# Altium schematic sheet keyboard.SchDoc
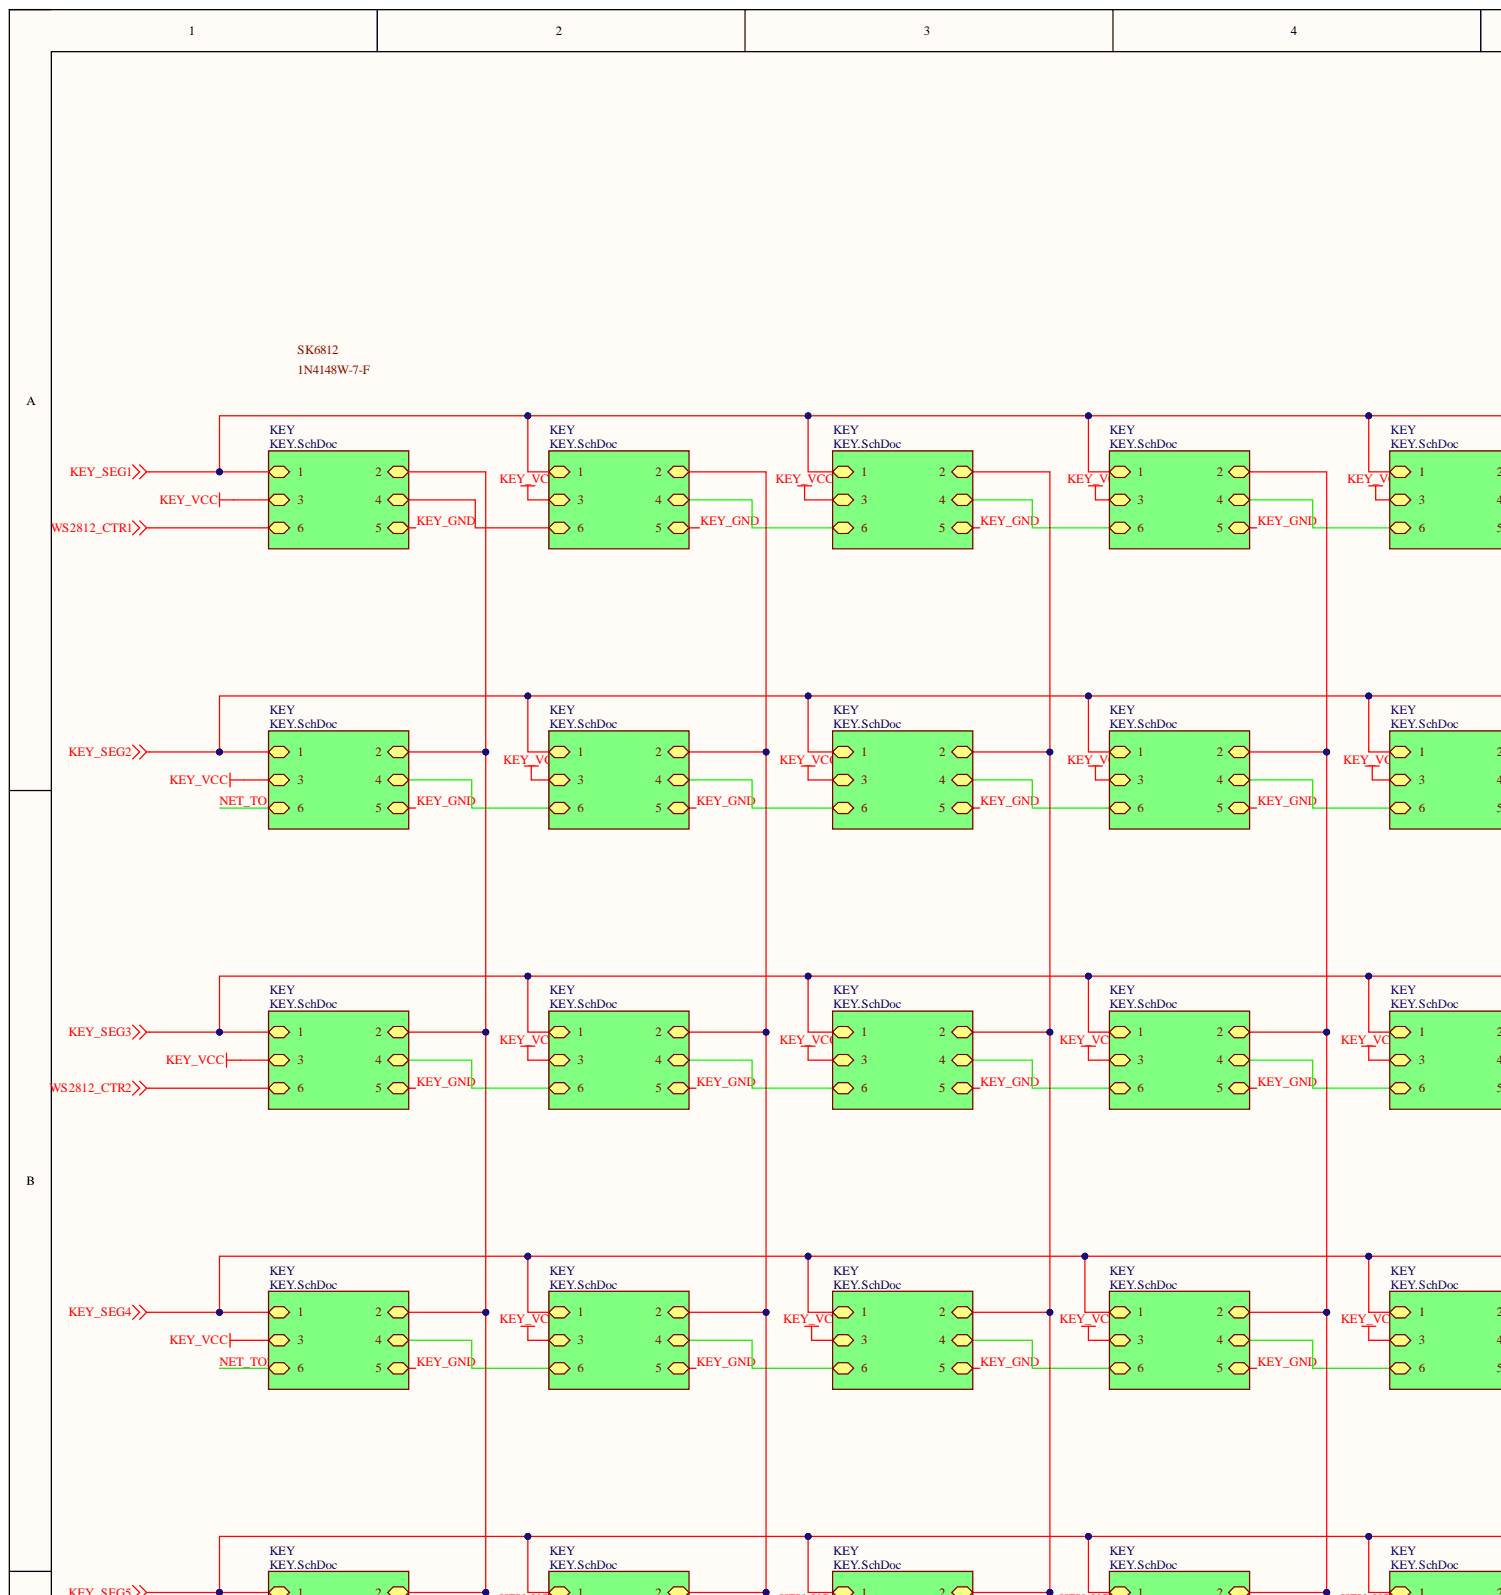
[diagram p0: keyboard.SchDoc, top left]
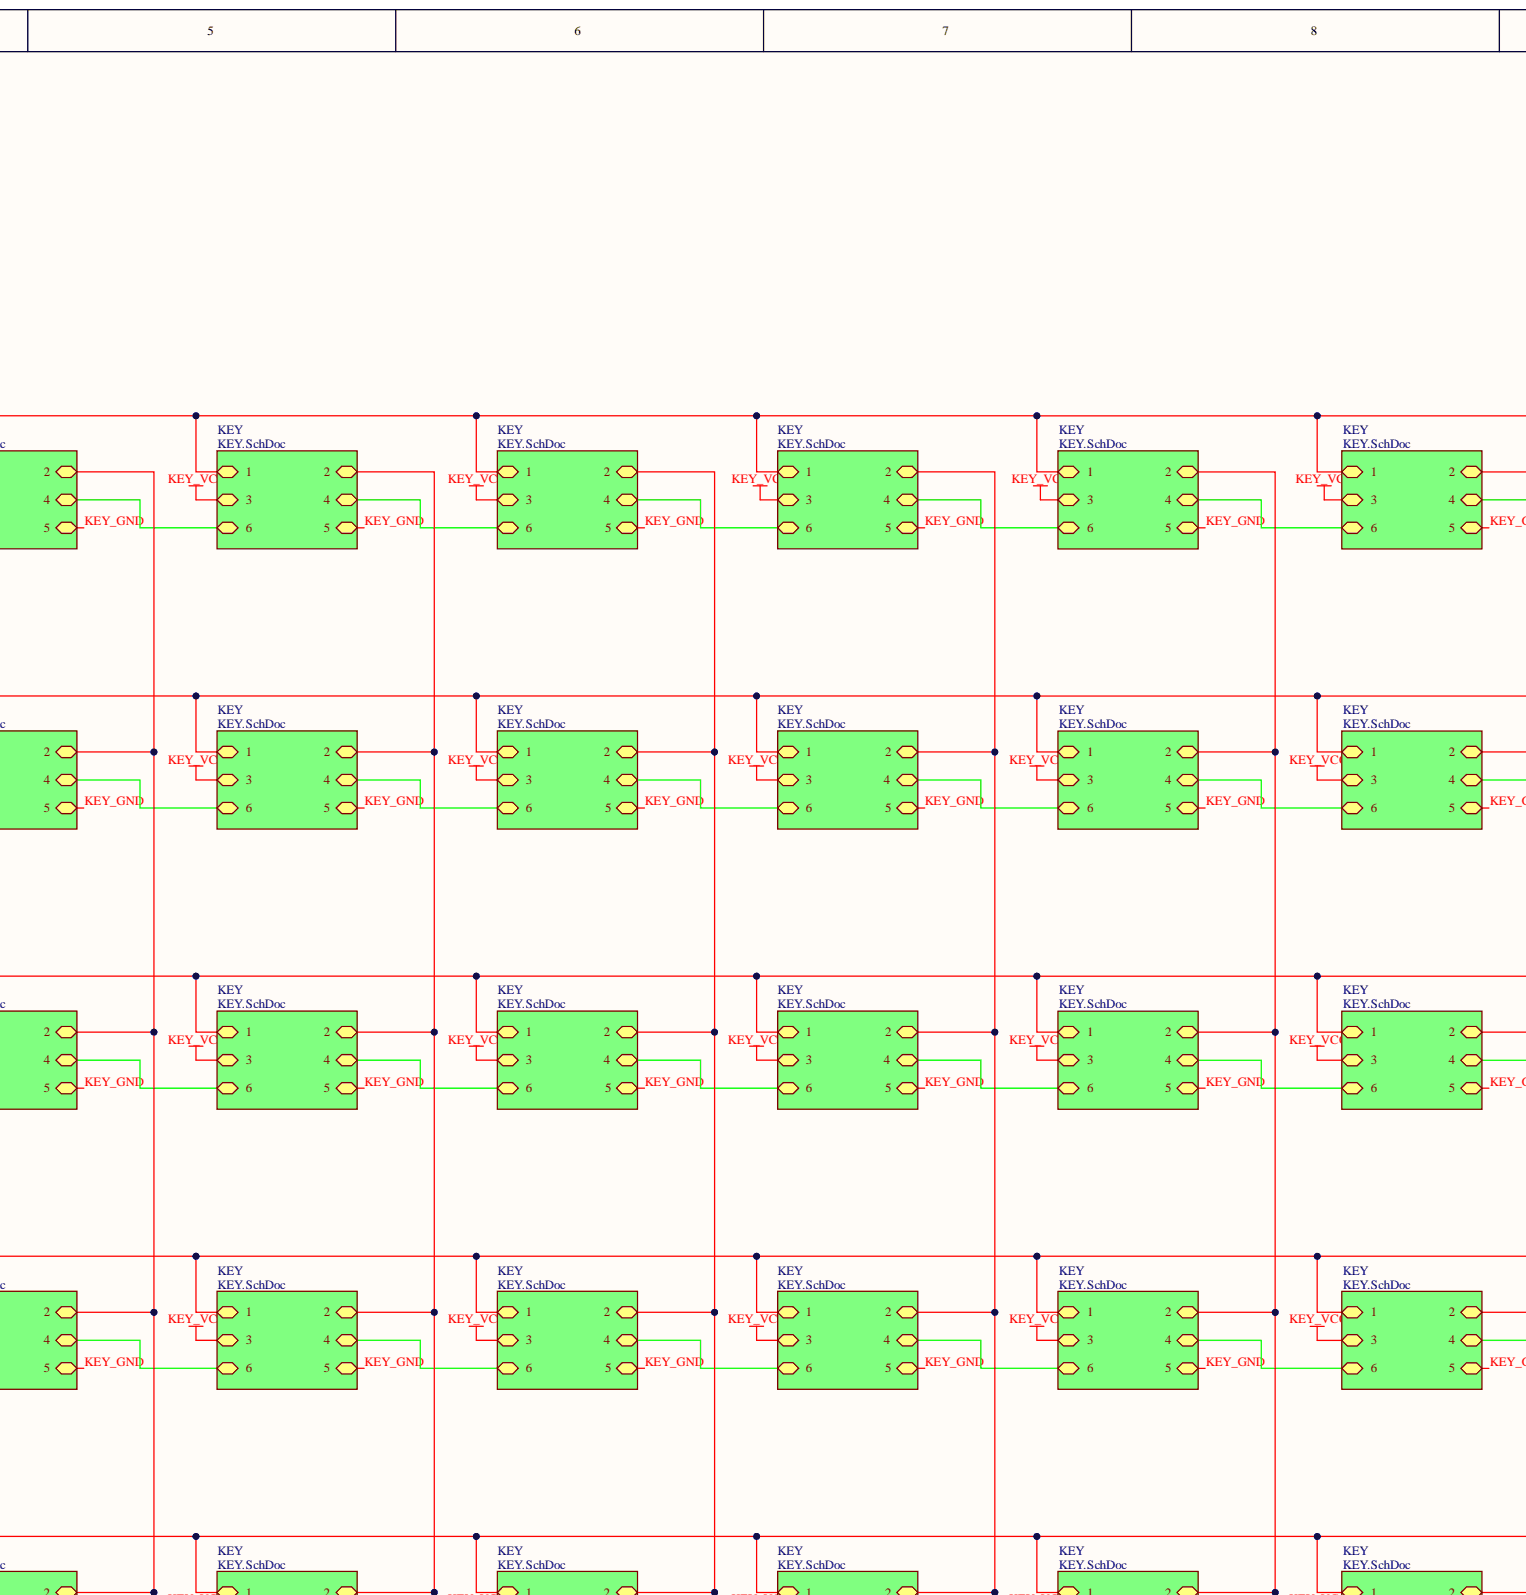
[diagram p1: keyboard.SchDoc, top centre]
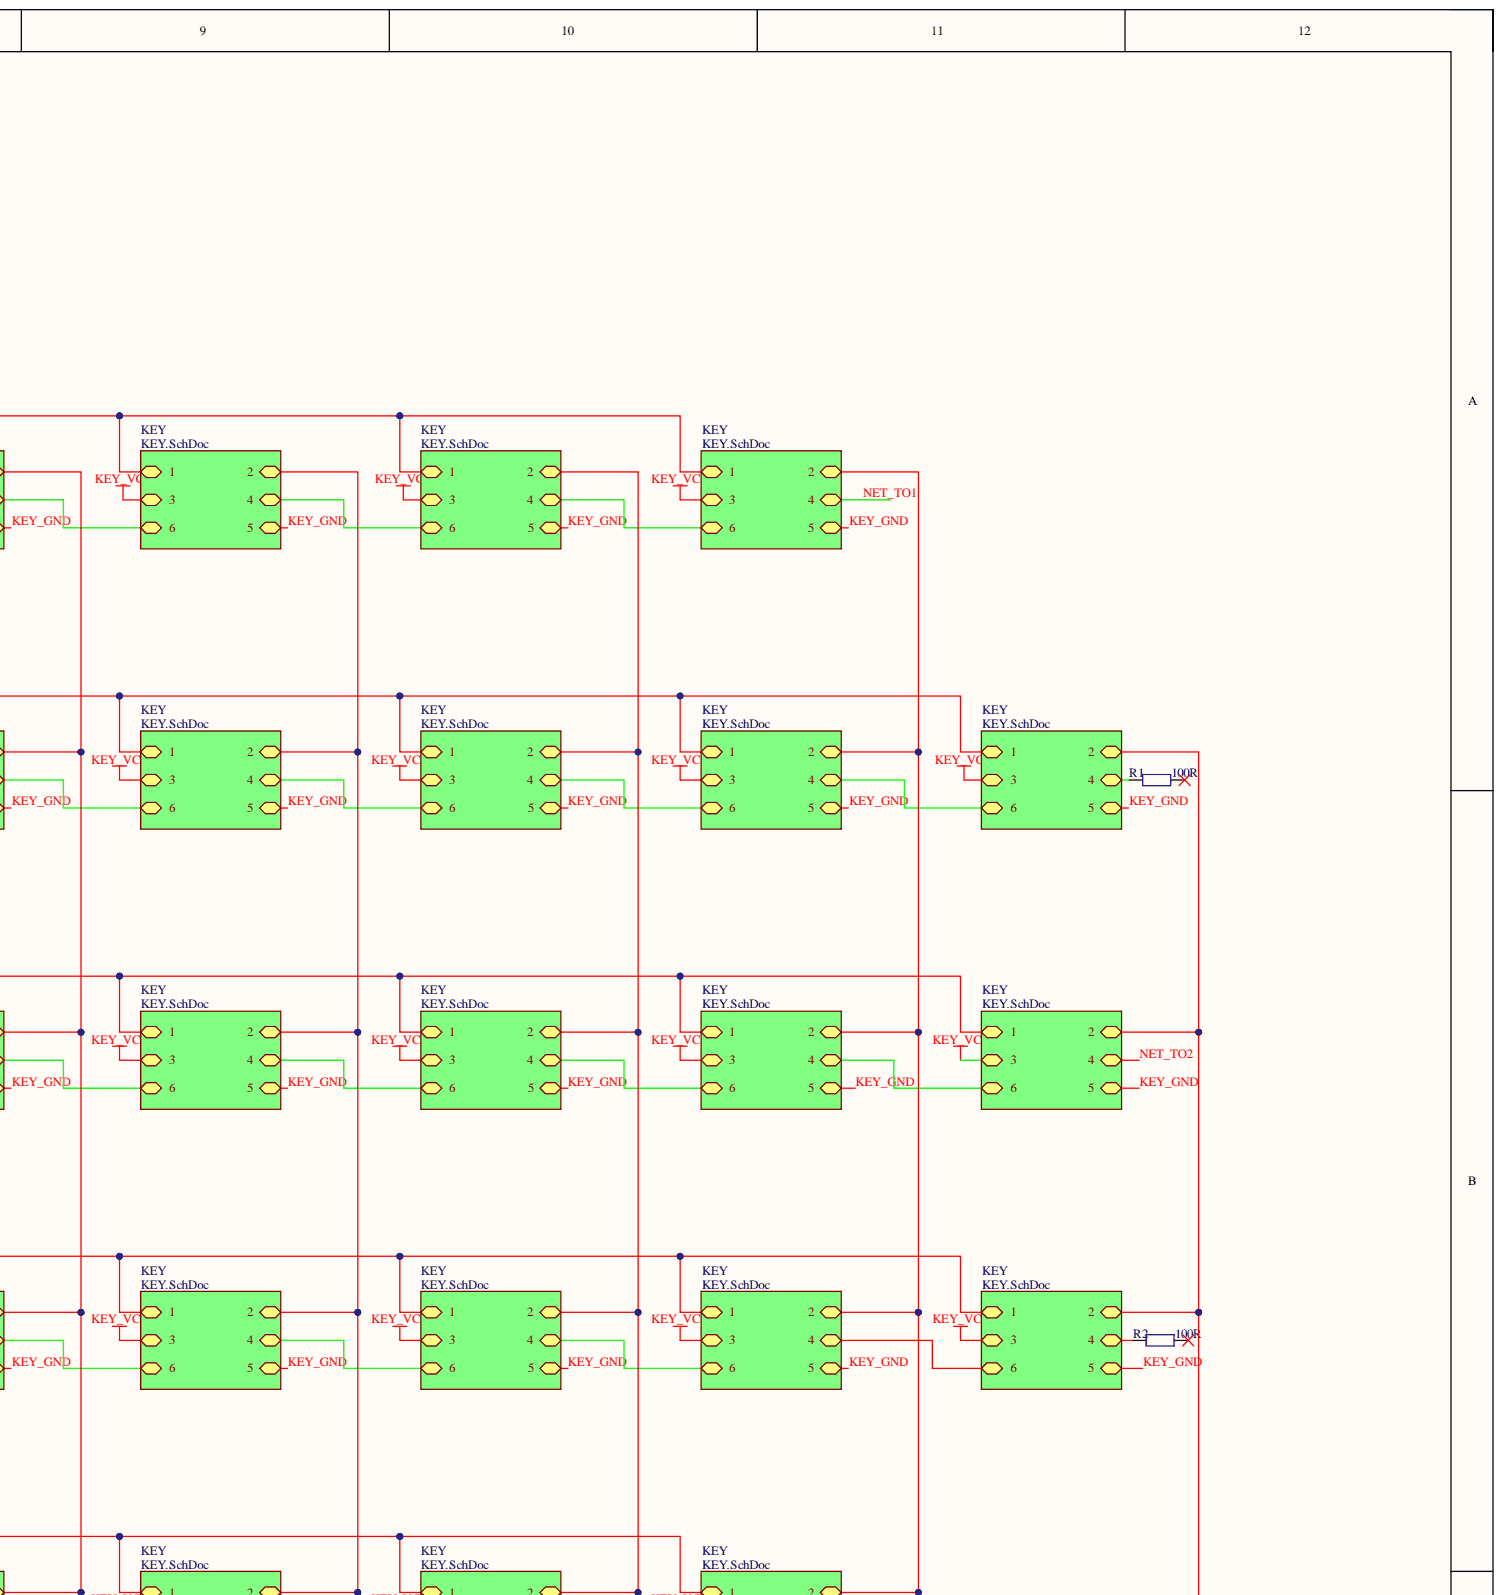
[diagram p2: keyboard.SchDoc, top right]
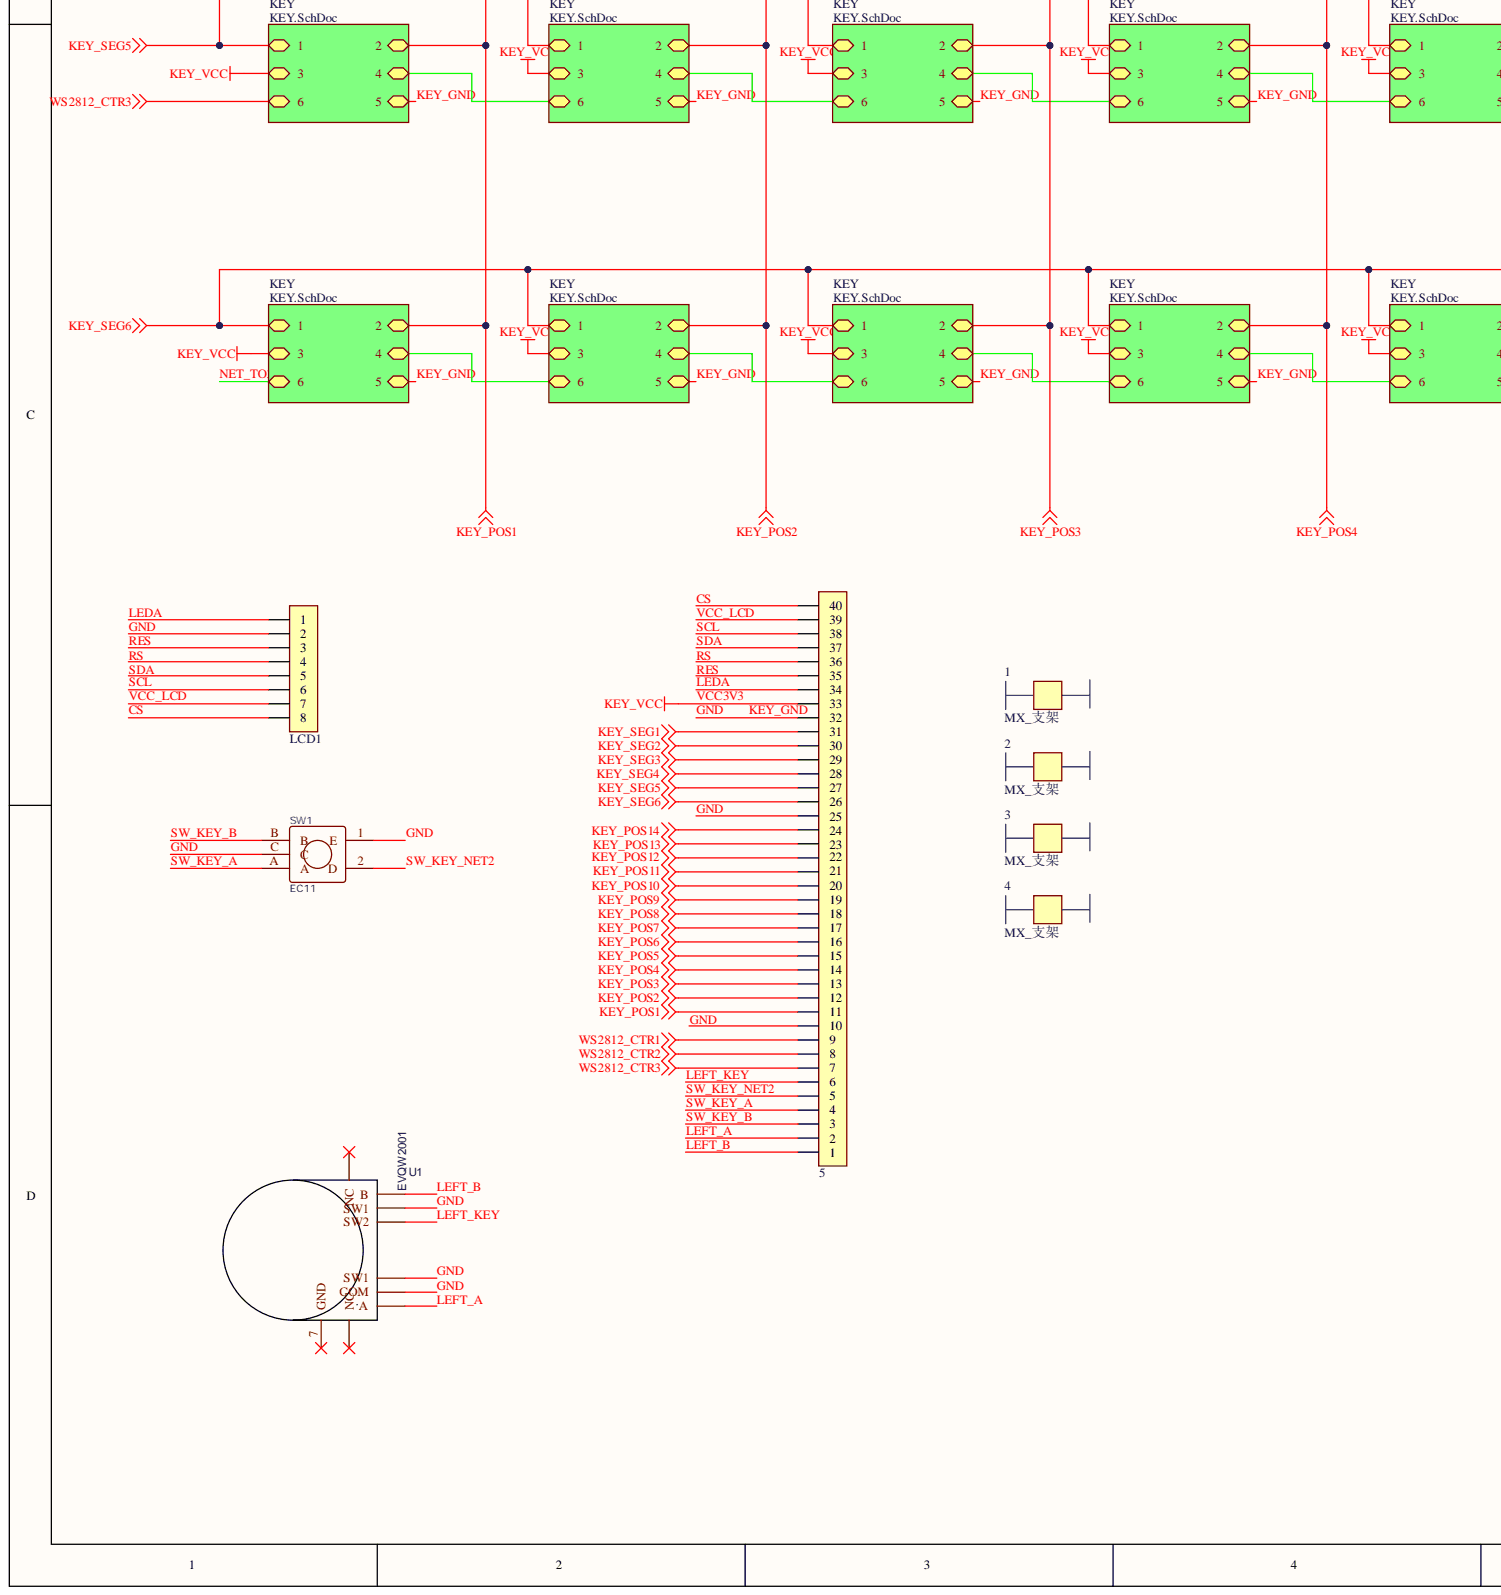
[diagram p3: keyboard.SchDoc, bottom left]
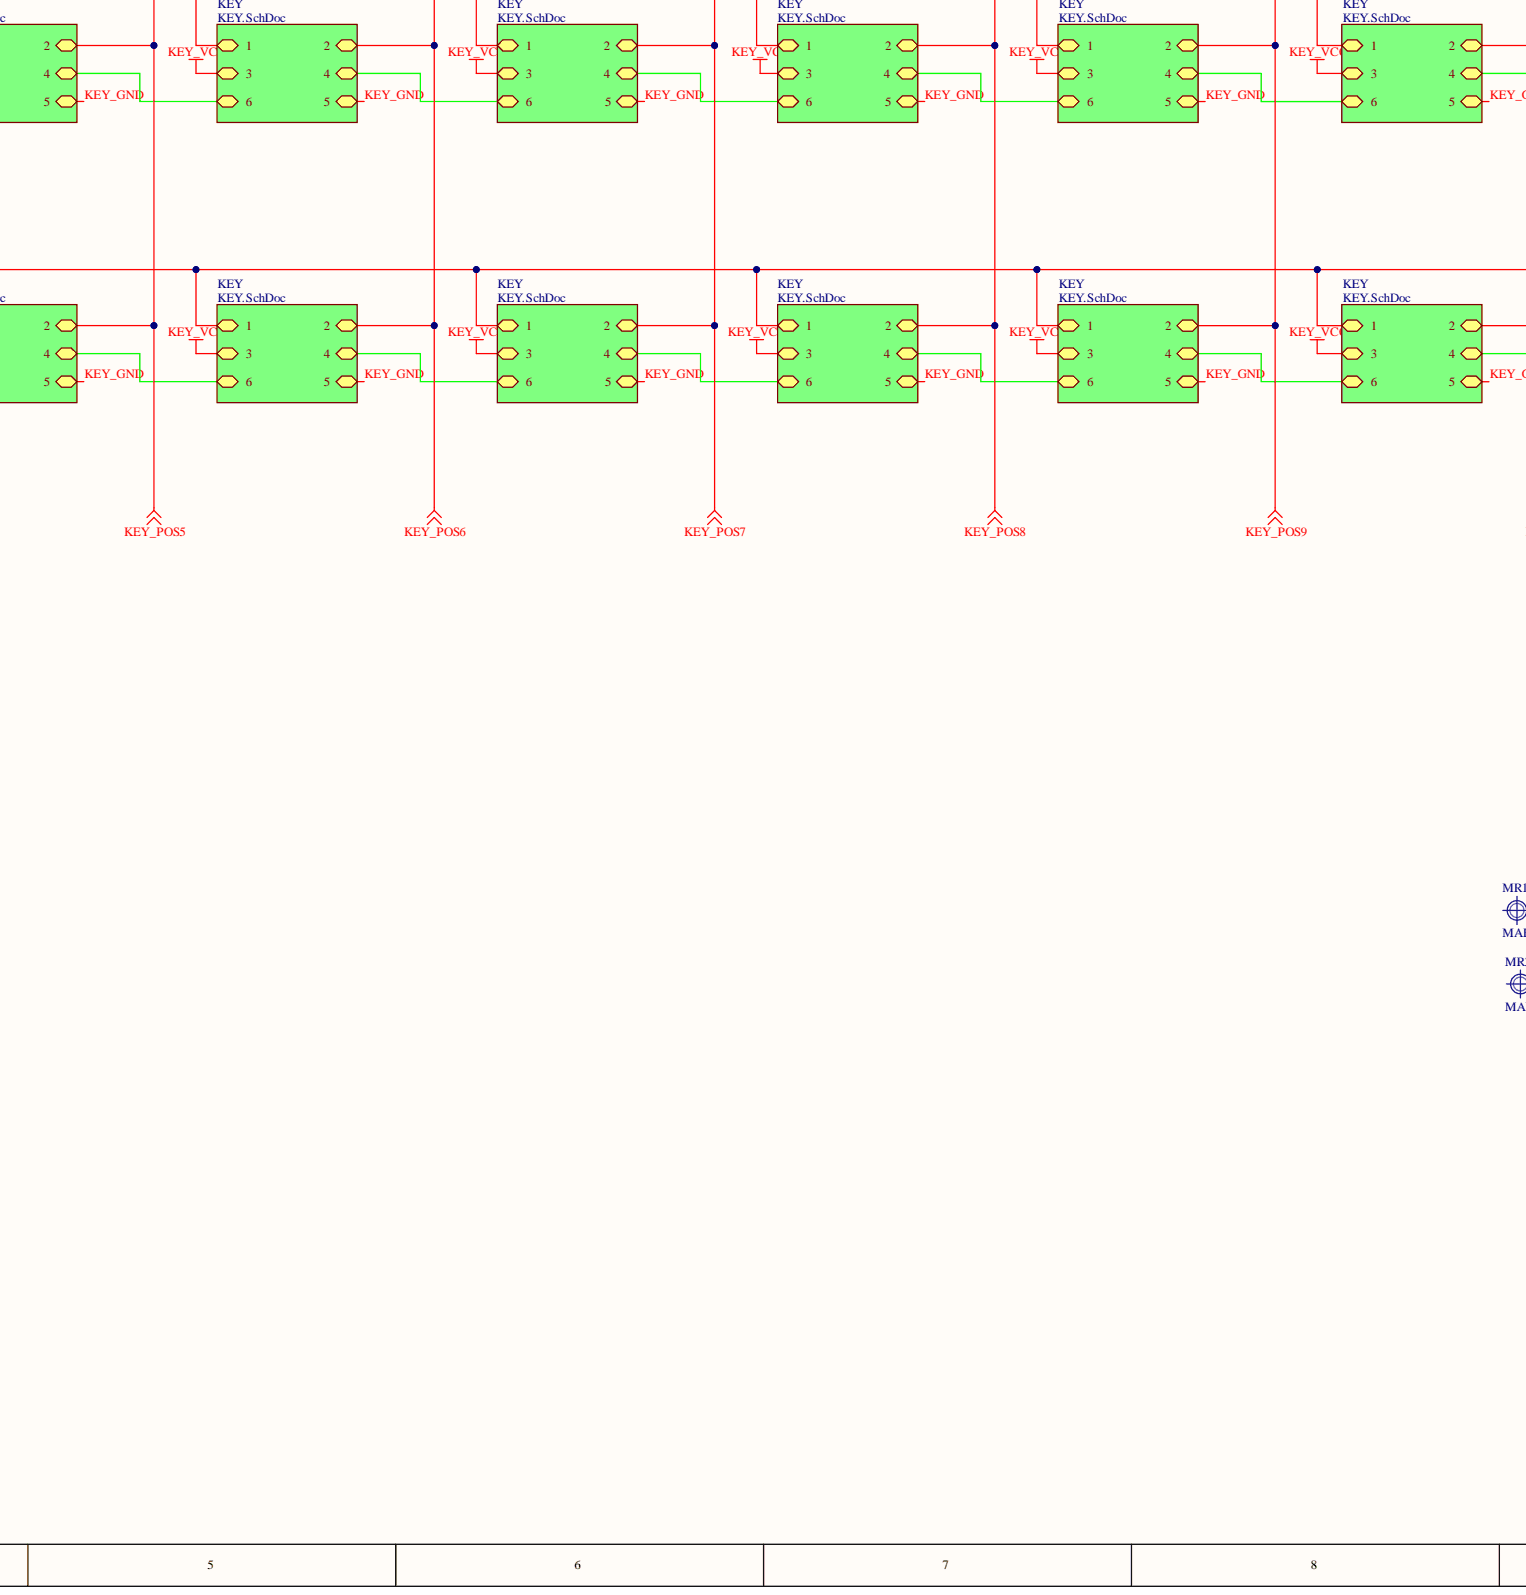
[diagram p4: keyboard.SchDoc, bottom centre]
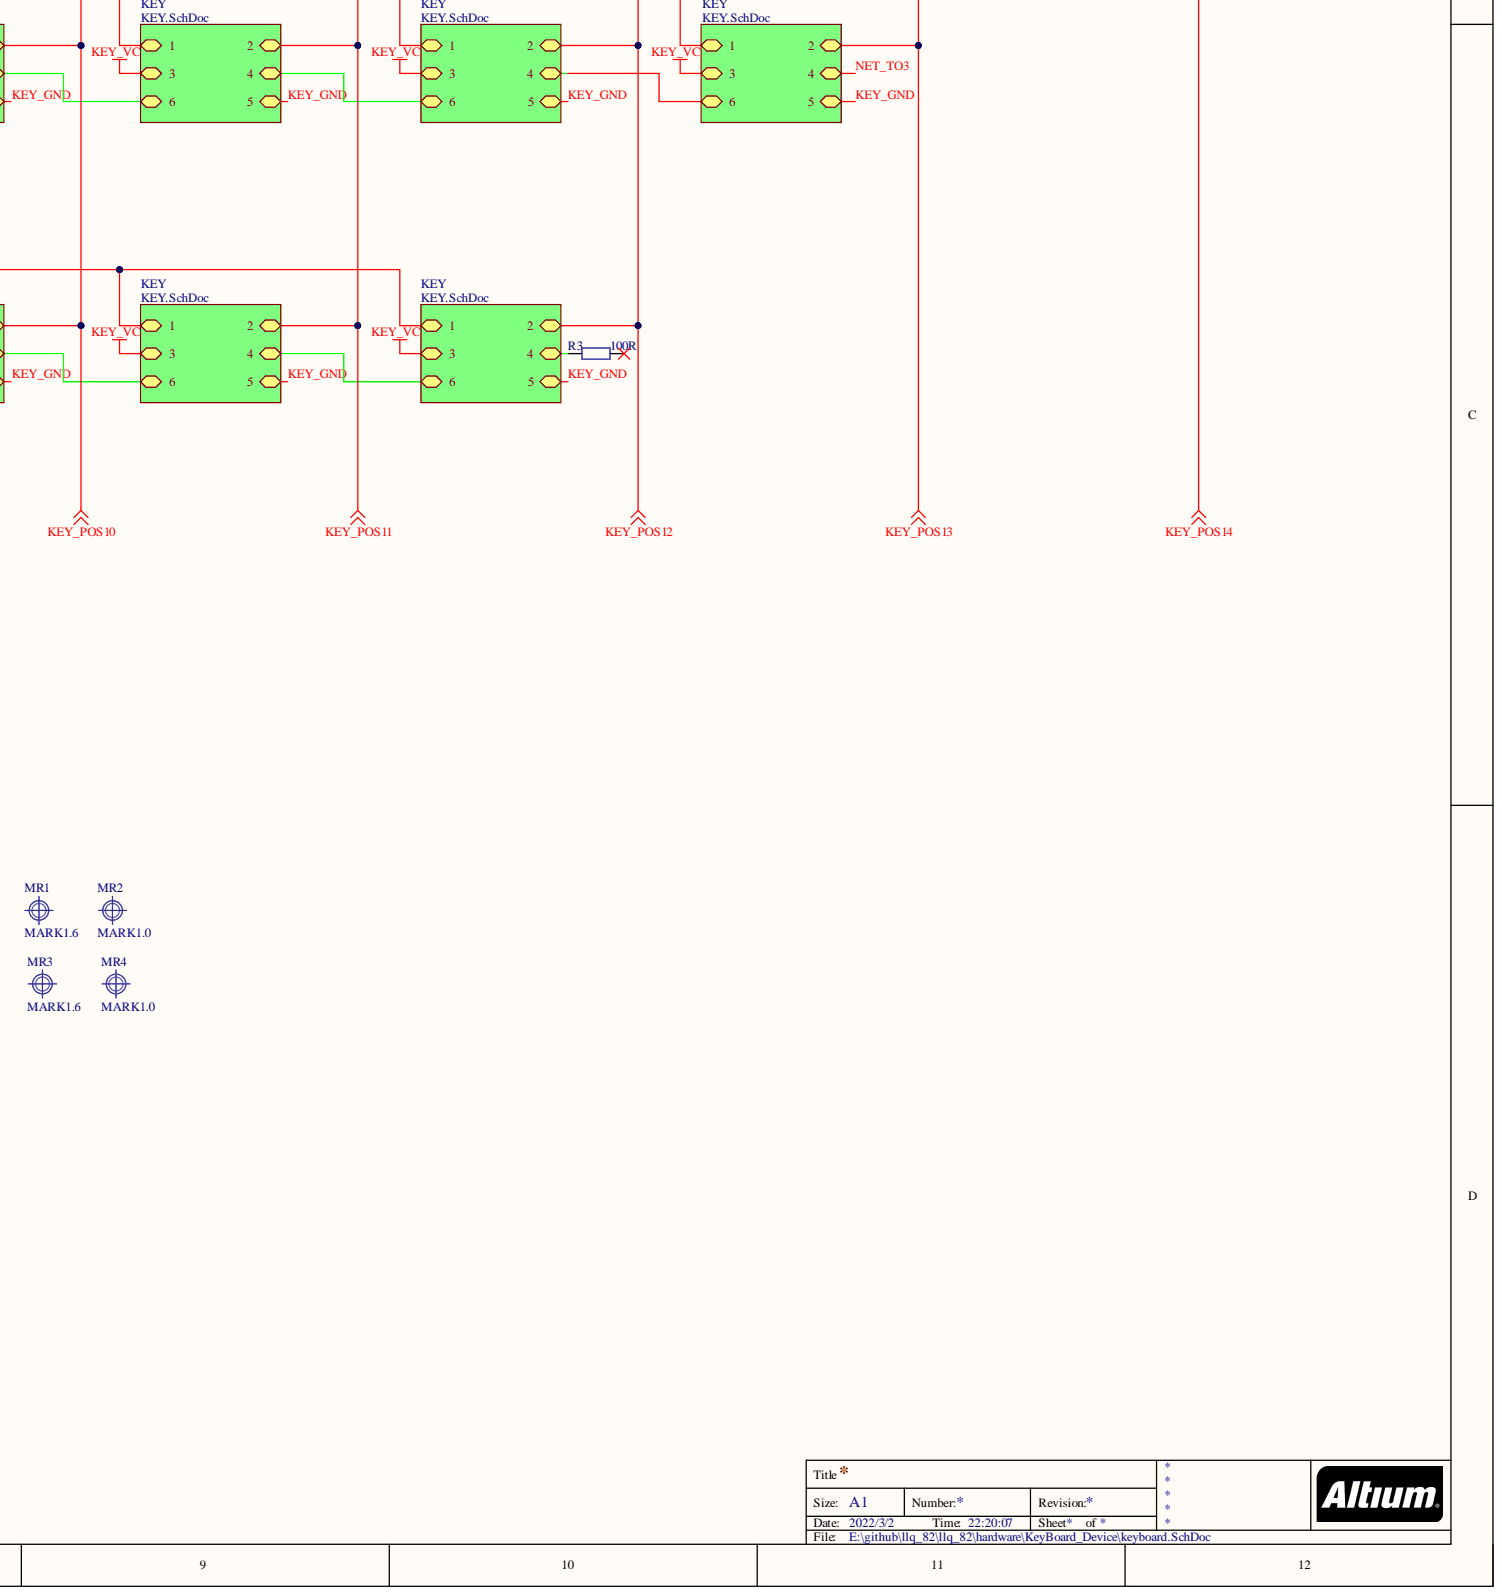
[diagram p5: keyboard.SchDoc, bottom right]

## Parts
1  MX_支架  [1x2]
2  MX_支架  [1x2]
3  MX_支架  [1x2]
4  MX_支架  [1x2]
5  FPC1.0-40P  [FPC0.5 2H-WS-40P]  (value not drawn)
LCD1  FPC1.0-8P  [FPC0.5 2H-WS-8P]  (value not drawn)
MR1  MARK1.6  [Mark 1.6]
MR2  MARK1.0  [Mark 1]
MR3  MARK1.6  [Mark 1.6]
MR4  MARK1.0  [Mark 1]
R1  100R  [R 0805_M]
R2  100R  [R 0805_M]
R3  100R  [R 0805_M]
SW1  EC11  [BOURNS_PEC11R-4XXXF-SXXXX]
U1  EVQW2001  [EVQWK2001 - duplicate]

## Nets
KEY_POS1: 5.11
KEY_POS2: 5.12
KEY_POS3: 5.13
KEY_POS4: 5.14
KEY_POS5: 5.15
KEY_POS6: 5.16
KEY_POS7: 5.17
KEY_POS8: 5.18
KEY_POS9: 5.19
KEY_POS10: 5.20
KEY_POS11: 5.21
KEY_POS12: 5.22
KEY_POS13: 5.23
KEY_POS14: 5.24
KEY_SEG1: 5.31
KEY_SEG2: 5.30
KEY_SEG3: 5.29
KEY_SEG4: 5.28
KEY_SEG5: 5.27
KEY_SEG6: 5.26
WS2812_CTR1: 5.9
WS2812_CTR2: 5.8
WS2812_CTR3: 5.7
CS: 5.40 LCD1.8
GND: 5.10 5.25 5.32 LCD1.2 SW1.1(E) SW1.C U1.2(SW1) U1.4(SW1) U1.5(COM)
LEDA: 5.34 LCD1.1
LEFT_A: 5.2 U1.6(A)
LEFT_B: 5.1 U1.1(B)
LEFT_KEY: 5.6 U1.3(SW2)
RES: 5.35 LCD1.3
RS: 5.36 LCD1.4
SCL: 5.38 LCD1.6
SDA: 5.37 LCD1.5
SW_KEY_A: 5.4 SW1.A
SW_KEY_B: 5.3 SW1.B
SW_KEY_NET2: 5.5 SW1.2(D)
VCC3V3: 5.33
VCC_LCD: 5.39 LCD1.7
NetR1_1: R1.1
NetR1_2: R1.2
NetR2_1: R2.1
NetR2_2: R2.2
NetR3_1: R3.1
NetR3_2: R3.2
NetU1_7: U1.7(GND)
NetU1_8: U1.8
4:
NET_TO1:
NET_TO2:
NET_TO3:

## Library data of the parts
5 = FPC-40P: FPC连接器; 版权归属: 黎明电子style; BOM名称: FPC连接器; Component Kind: Standard; Library Name: FPC连接器.SchLib; 学习资源: https://lmdzstyle.taobao.com/
LCD1 = FPC-8P: FPC连接器; 版权归属: 黎明电子style; BOM名称: FPC连接器; Component Kind: Standard; Library Name: FPC连接器.SchLib; 学习资源: https://lmdzstyle.taobao.com/
MR1-MR4 = MARK: 光学定位点; Component Kind: Standard; Library Name: 4.1 - 未分类器件.SchLib
R1-R3 = R: 贴片电阻; Component Kind: Standard; Library Name: 0.1 - 电阻-电容-电感.SchLib
U1 = EVQW2001: Contributor: jam_dan; Component Kind: Standard; spicePre: U; spiceSymbolName: EVQW2001; Library Name: 机械键盘.SchLib
1-4 = MX_支架: Component Kind: Standard; Library Name: 机械键盘.SchLib
SW1 = EC11: Component Kind: Standard; Library Name: 机械键盘.SchLib
